# Altium schematic sheet 07_Wireless_Communication.SchDoc
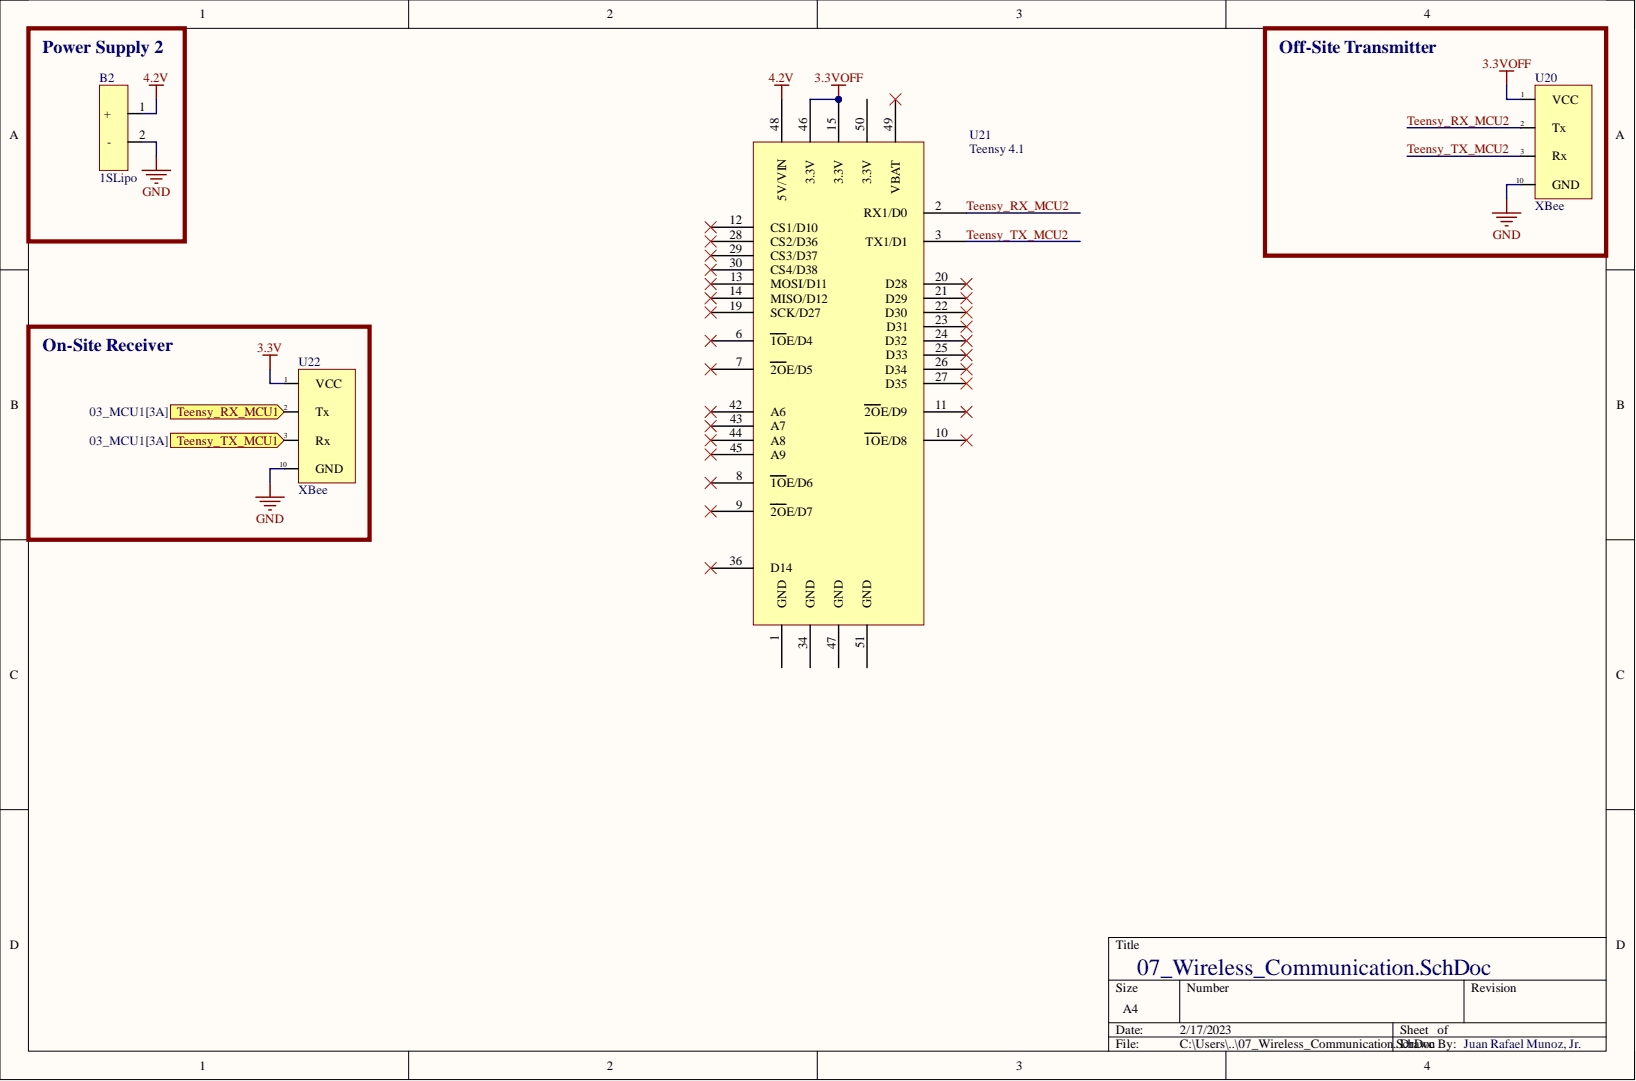
[diagram p0: 07_Wireless_Communication.SchDoc]

## Parts
B2  1SLipo  [1SLiPo]
U20  XBee  [XBee]
U21  Teensy 4.1  [Teensy 4.1]
U22  XBee  [XBee]

## Ports
Teensy_RX_MCU1, Teensy_TX_MCU1

## Nets
3.3V: U22.1(VCC)
3.3VOFF: U20.1(VCC) U21.15(3.3V) U21.46(3.3V)
4.2V: B2.1 U21.48(5V/VIN)
GND: B2.2 U20.10(GND) U22.10(GND)
Teensy_RX_MCU1: U22.2(Tx) ; port Teensy_RX_MCU1
Teensy_RX_MCU2: U20.2(Tx) U21.2(RX1/D0)
Teensy_TX_MCU1: U22.3(Rx) ; port Teensy_TX_MCU1
Teensy_TX_MCU2: U20.3(Rx) U21.3(TX1/D1)

Not connected: U21 1(GND) 6(1OE/D4) 7(2OE/D5) 8(1OE/D6) 9(2OE/D7) 10(1OE/D8) 11(2OE/D9) 12(CS1/D10) 13(MOSI/D11) 14(MISO/D12) 19(SCK/D27) 20(D28) 21(D29) 22(D30) 23(D31) 24(D32) 25(D33) 26(D34) 27(D35) 28(CS2/D36) 29(CS3/D37) 30(CS4/D38) 34(GND) 36(D14) 42(A6) 43(A7) 44(A8) 45(A9) 47(GND) 49(VBAT) 50(3.3V) 51(GND)

## Library data of the parts
U21 = Teensy 4.1: RT1062 Teensy 4.1 - ARM® Cortex®-M7 MCU 32-Bit Embedded Evaluation Board 600 MHz 100 mA RTC Built-in microSD Card reader Arduino IDE compatible; Manufacturer: SparkFun; Manufacturer Part Number: DEV-16996; Category: Embedded Processors and Controllers; Component Kind: Standard; Pricing 1: 1=31.88000 (USD); RoHS: No; Stock 1: 300; Supplier 1: Digi-Key; Supplier Part Number 1: 1568-DEV-16996-ND; Library Name: Ground Support_Schlib.SchLib
B2 = 1SLiPo: Component Kind: Standard; Library Name: Ground Support_Schlib.SchLib
U20 U22 = XBee: Component Kind: Standard; Library Name: Ground Support_Schlib.SchLib
